# Altium schematic sheet DeadTimeDriver_Leg.SchDoc
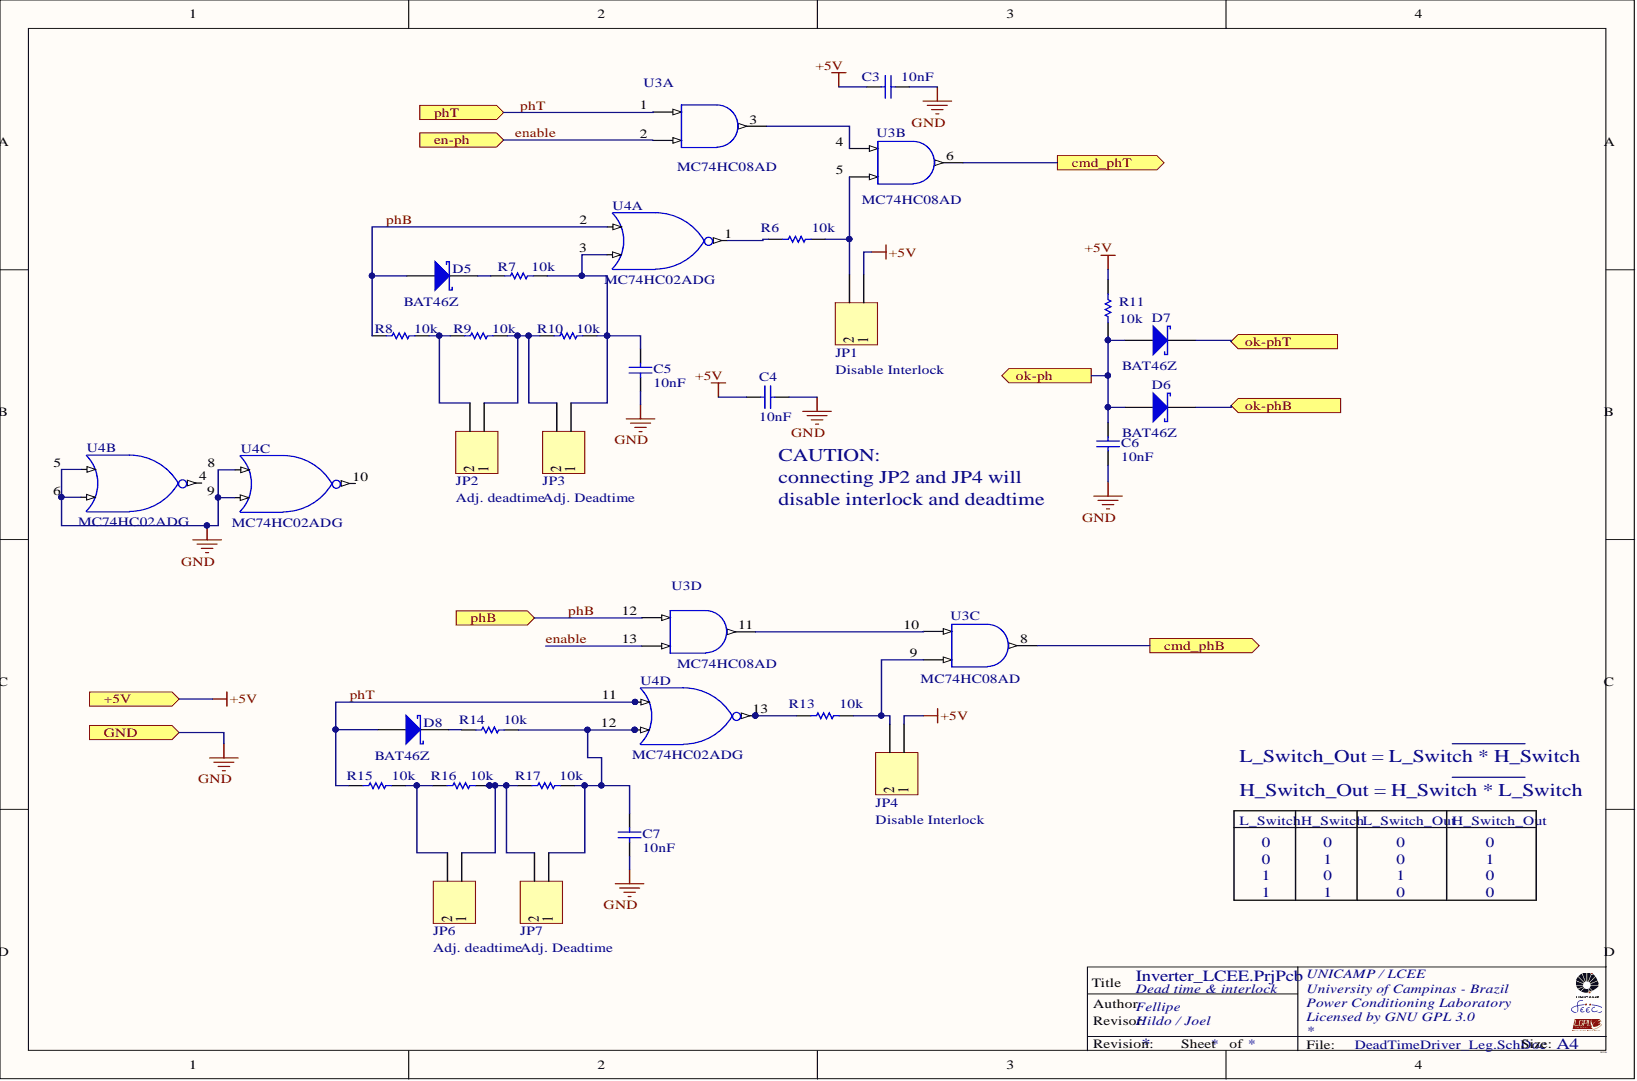
[diagram p0: DeadTimeDriver_Leg.SchDoc]

## Parts
C3  value 10nF  [0805_W]
C4  value 10nF  [0805_W]
C5  value 10nF  [0805_W]
C6  value 10nF  [0805_W]
C7  value 10nF  [0805_W]
D5  value BAT46Z  [SOD-123]
D6  value BAT46Z  [SOD-123]
D7  value BAT46Z  [SOD-123]
D8  value BAT46Z  [SOD-123]
JP1  value Disable Interlock  [Jumper]
JP2  value Adj. deadtime  [Jumper]
JP3  value Adj. Deadtime  [Jumper]
JP4  value Disable Interlock  [Jumper]
JP6  value Adj. deadtime  [Jumper]
JP7  value Adj. Deadtime  [Jumper]
R6  value 10k  [0805_W]
R7  value 10k  [0805_W]
R8  value 10k  [0805_W]
R9  value 10k  [0805_W]
R10  value 10k  [0805_W]
R11  value 10k  [0805_W]
R13  value 10k  [0805_W]
R14  value 10k  [0805_W]
R15  value 10k  [0805_W]
R16  value 10k  [0805_W]
R17  value 10k  [0805_W]
U3  value MC74HC08AD  [SOIC-14N]  (parts A,B,C,D)
U4  value MC74HC02ADG  [SOIC-14N]  (parts A,B,C,D)

## Ports
+5V, CMD_PHB, CMD_PHT, EN-PH, GND, OK-PH, OK-PHB, OK-PHT, PHB, PHT

## Nets
+5V: C3.1 C4.1 JP1.1 JP4.1 R11.2 U3.14 U4.14 ; port +5V
ENABLE: U3.2 U3.13 ; port EN-PH
GND: C3.2 C4.2 C5.2 C6.2 C7.2 U3.7 U4.5 U4.6 U4.7 U4.8 U4.9 ; port GND
PHB: D5.1 R8.1 U3.12 U4.2 ; port PHB
PHT: D8.1 R15.1 U3.1 U4.11 ; port PHT
NetC5_1: C5.1 JP3.1 R7.2 R10.2 U4.3
NetC6_1: C6.1 D6.1 D7.1 R11.1 ; port OK-PH
NetC7_1: C7.1 JP7.1 R14.2 R17.2 U4.12
NetD5_2: D5.2 R7.1
NetD6_2: D6.2 ; port OK-PHB
NetD7_2: D7.2 ; port OK-PHT
NetJP1_2: JP1.2 R6.2 U3.5
NetJP2_1: JP2.1 JP3.2 R9.2 R10.1
NetJP2_2: JP2.2 R8.2 R9.1
NetJP4_2: JP4.2 R13.2 U3.9
NetJP6_1: JP6.1 JP7.2 R16.2 R17.1
NetJP6_2: JP6.2 R15.2 R16.1
NetR6_1: R6.1 U4.1
NetR13_1: R13.1 U4.13
NetU3_3: U3.3 U3.4
NetU3_6: U3.6 ; port CMD_PHT
NetU3_8: U3.8 ; port CMD_PHB
NetU3_10: U3.10 U3.11
N00004:
N00005:

Not connected: D8 2; R14 1; U4 4 10

## Library data of the parts
C3 C4 = Capacitor_nao_polarizado: Capacitor nao polarizado; Component Kind: Standard; Value: Value; Library Name: Sch_LCEE.SchLib
C5-C7 = Cap_0805: Capacitor; Component Kind: Standard; LatestRevisionDate: 17-Jul-2002; LatestRevisionNote: Re-released for DXP Platform.; PackageReference: RAD-0.3; Published: 8-Jun-2000; Signal Integrity: Cap; Simulation: CAP; Value: Value; Library Name: Inversor_LCEE.SchLib; Publisher: Altium Limited
D5-D8 = D Schottky: Schottky Diode; Code_JEDEC: DO-214-AA; Component Kind: Standard; LatestRevisionDate: 17-Jul-2002; LatestRevisionNote: Re-released for DXP Platform.; Library Name: Miscellaneous Devices.IntLib; PackageDescription: DO-214-AA/SMB; 2 C-Bend Leads; Body 5.3 x 3.6 mm, inc. leads (LxW); PackageReference: SMB; Published: 8-Jun-2000; Publisher: Altium Limited
  D5-D7: Signal Integrity: D Schottky; Simulation: SKYDIODE
JP1 JP3 JP4 JP7 = Header 2: Header, 2-Pin; Manufacturer: Generic Components; Class: Connector; Component Kind: Standard; Signal Integrity: Connector; Library Name: AQAC.SCHLIB
JP2 JP6 = Header 2: Header, 2-Pin; Manufacturer: Generic Components; Class: Connector; Value: Adj. deadtime; Component Kind: Standard; Library Name: AQAC.SCHLIB
  JP6: Signal Integrity: Connector
R6-R10 R13-R17 = Res_0805: Resistor; Manufacturer: Generic Components; Class: Passive; Component Kind: Standard; Published: 08/06/2000; Signal Integrity: Res1; SubClass: Resistor; Value: Value; Library Name: Inversor_LCEE.SchLib; Publisher: Altium Hobart Technology Centre
  R6-R10 R13 R15-R17: Simulation: RESISTOR
R11 = Res_0805: Resistor; Manufacturer: Generic Components; Class: Passive; Value: 10k; Component Kind: Standard; Published: 08/06/2000; Signal Integrity: Res1; SubClass: Resistor; Value: Value; Library Name: Inversor_LCEE.SchLib; Publisher: Altium Hobart Technology Centre
U3 = MC74HC08AD: Quad 2-Input AND Gate; Code_IPC: SOIC127P600-14; Component Kind: Standard; ComponentLink1Description: Manufacturer Link; ComponentLink1URL: http://www.onsemi.com/site/products/parametrics/0,4446,247,00.html; ComponentLink2Description: Ibis Model; ComponentLink2URL: http://www.onsemi.com/pub/Collateral/MC54HC08AJ.IBS; DatasheetVersion: 1989; LatestRevisionDate: 13-Apr-2006; LatestRevisionNote: IPC-7351 Footprint Added.; Library Name: Motorola Logic Gate.IntLib; PackageDescription: 14-Pin Small Outline Integrated Circuit 1.27 mm Pitch; PackageReference: 751A-02; PackageVersion: 1991; PCB3D: 751A-02; Published: 17-Jul-2002; Signal Integrity: MC74HC08AD; Publisher: Altium Limited
U4 = Double 2-input NOR: SN74AUP2G02DCUR; Component Kind: Standard; Library Name: Sch_LCEE.SchLib
